# Altium schematic sheet Sheet1.SchDoc
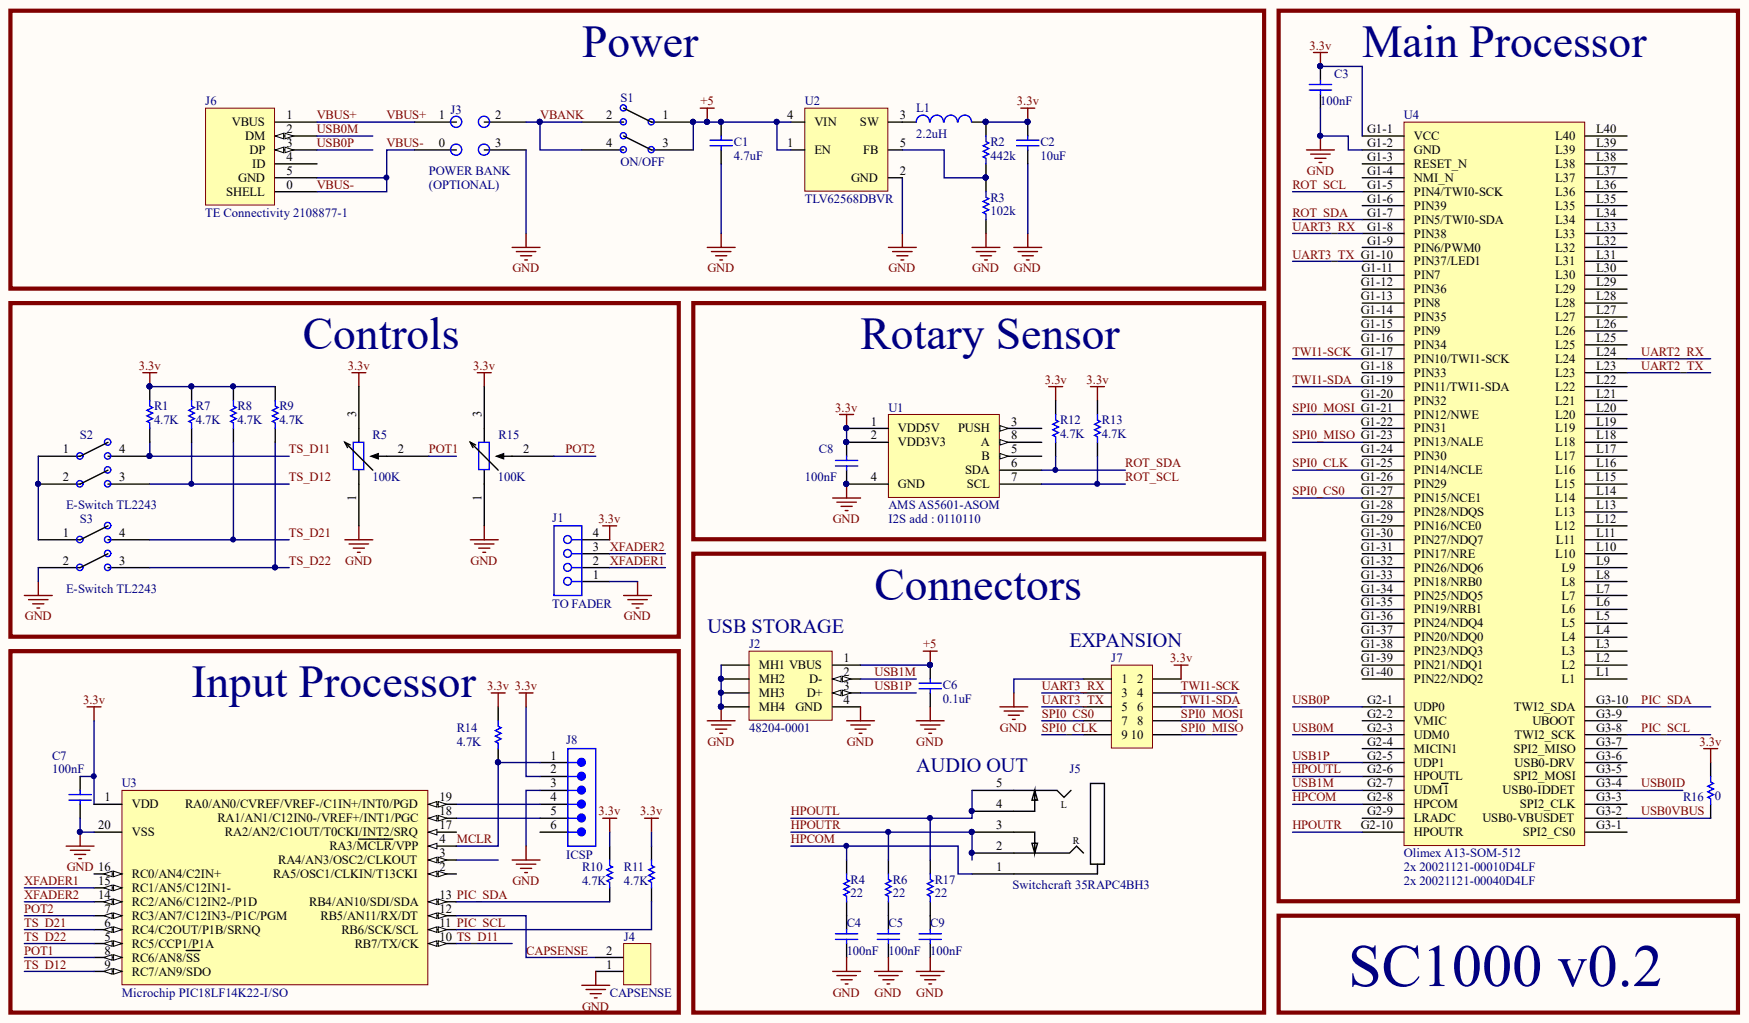
[diagram p0: Sheet1.SchDoc]

## Parts
C1  4.7uF  [CAPC3216X88X50NL20T25]
C2  10uF  [CAPC3216X180X50NL20T25]
C3  100nF  [CAPC3216X88X50NL20T25]
C4  100nF  [CAPC3216X88X50NL20T25]
C5  100nF  [CAPC3216X88X50NL20T25]
C6  0.1uF  [CAPC3216X110X50NL20T25]
C7  100nF  [CAPC3216X88X50NL20T25]
C8  100nF  [CAPC3216X88X50NL20T25]
C9  100nF  [CAPC3216X88X50NL20T25]
J1  TO FADER  [PCB-rr2vrocx47ltzxs94tsj-1]
J2  48204-0001  [MOLX-48204-0001_V]
J3  POWER BANK  [PCB-640863-1]
J4  CAPSENSE  [HDR102]
J5  Switchcraft 35RAPC4BH3  [PCB-i49478ydpijisqw62jjz-1]
J6  TE Connectivity 2108877-1  [PCB-swvmklzeh1x4bfefwydg-1]
J7  samtec TSW-105-07-G-D  [PCB-2.54]  (value not drawn)
J8  ICSP  [PCB-2481460-1]
L1  2.2uH  [PCB-fuzgio0l72uhvfuauccx-1]
R1  4.7K  [RESC3116X65X40NL10T20]
R2  442k  [RESC3116X65X40NL10T20]
R3  102k  [RESC3116X65X40NL10T20]
R4  22  [RESC3216X60X45NL10T20]
R5  100K  [PCB-shbx6jp5maipb9mq302d-1]
R6  22  [RESC3216X60X45NL10T20]
R7  4.7K  [RESC3116X65X40NL10T20]
R8  4.7K  [RESC3116X65X40NL10T20]
R9  4.7K  [RESC3116X65X40NL10T20]
R10  4.7K  [RESC3116X65X40NL10T20]
R11  4.7K  [RESC3116X65X40NL10T20]
R12  4.7K  [RESC3116X65X40NL10T20]
R13  4.7K  [RESC3116X65X40NL10T20]
R14  4.7K  [RESC3116X65X40NL10T20]
R15  100K  [PCB-shbx6jp5maipb9mq302d-1]
R16  0  [RESC1608X55X25NL10T15]
R17  22  [RESC3216X60X45NL10T20]
S1  ON/OFF  [PCB-6le2ne6zhzdyil25dd15-1]
S2  E-Switch TL2243  [PCB-9wmaaupyl5r06yccozck-1]
S3  E-Switch TL2243  Manufacturer Part Number: TL2243  [PCB-9wmaaupyl5r06yccozck-1]
U1  AMS AS5601-ASOM  [PCB-q2hh09f3jnaqliukgvym-1]
U2  TLV62568DBVR  [TLV62568DBVR]
U3  Microchip PIC18LF14K22-I/SO  Manufacturer Part Number: PIC18LF14K22-I/SO  [SOIC-SO20_N]
U4  Olimex A13-SOM-512  [PCB-ys24v96rmxr2imnz69ba-1]

## Nets
3.3V: C2.2 C3.2 C7.2 C8.2 J1.4 J7.2 J8.2 L1.2 R1.2 R2.2 R5.3 R7.2 R8.2 R9.2 R10.2 R11.2 R12.2 R13.2 R14.2 R15.3 R16.2 U1.1(VDD5V) U1.2(VDD3V3) U3.1(VDD) U4.G1-1(VCC)
+5: C1.2 C6.2 J2.1(VBUS) S1.1 S1.3 U2.1(EN) U2.4(VIN)
GND: C1.1 C2.1 C3.1 C4.1 C5.1 C6.1 C7.1 C8.1 C9.1 J1.1 J2.4(GND) J2.MH1 J2.MH2 J2.MH3 J2.MH4 J3.3 J4.1 J7.1 J8.3 R3.1 R5.1 R15.1 S3.2 U1.4(GND) U2.2(GND) U3.20(VSS) U4.G1-2(GND)
CAPSENSE: J4.2 U3.12(RB5/AN11/RX/DT)
HPCOM: J5.1 R4.2 U4.G2-8(HPCOM)
HPOUTL: J5.4 J5.5 R17.2 U4.G2-6(HPOUTL)
HPOUTR: J5.2 J5.3 R6.2 U4.G2-10(HPOUTR)
MCLR: J8.1 R14.1 U3.4(RA3/MCLR/VPP)
PIC_SCL: R11.1 U3.11(RB6/SCK/SCL) U4.G3-8(TWI2_SCK)
PIC_SDA: R10.1 U3.13(RB4/AN10/SDI/SDA) U4.G3-10(TWI2_SDA)
POT1: R5.2 U3.8(RC6/AN8/SS)
POT2: R15.2 U3.7(RC3/AN7/C12IN3-/P1C/PGM)
ROT_SCL: R13.1 U1.7(SCL) U4.G1-5(PIN4/TWI0-SCK)
ROT_SDA: R12.1 U1.6(SDA) U4.G1-7(PIN5/TWI0-SDA)
SPI0_CLK: J7.9 U4.G1-25(PIN14/NCLE)
SPI0_CS0: J7.7 U4.G1-27(PIN15/NCE1)
SPI0_MISO: J7.10 U4.G1-23(PIN13/NALE)
SPI0_MOSI: J7.8 U4.G1-21(PIN12/NWE)
TS_D11: R1.1 S2.4 U3.10(RB7/TX/CK)
TS_D12: R7.1 S2.3 U3.9(RC7/AN9/SDO)
TS_D21: R8.1 S3.4 U3.6(RC4/C2OUT/P1B/SRNQ)
TS_D22: R9.1 S3.3 U3.5(RC5/CCP1/P1A)
TWI1-SCK: J7.4 U4.G1-17(PIN10/TWI1-SCK)
TWI1-SDA: J7.6 U4.G1-19(PIN11/TWI1-SDA)
UART2_RX: U4.L24
UART2_TX: U4.L23
UART3_RX: J7.3 U4.G1-8(PIN38)
UART3_TX: J7.5 U4.G1-10(PIN37/LED1)
USB0ID: U4.G3-4(USB0-IDDET)
USB0M: J6.2(DM) U4.G2-3(UDM0)
USB0P: J6.3(DP) U4.G2-1(UDP0)
USB0VBUS: R16.1 U4.G3-2(USB0-VBUSDET)
USB1M: J2.2(D-) U4.G2-7(UDM1)
USB1P: J2.3(D+) U4.G2-5(UDP1)
VBANK: J3.2 S1.2 S1.4
VBUS+: J3.1 J6.1(VBUS)
VBUS-: J3.0 J6.0(SHELL) J6.5(GND)
XFADER1: J1.2 U3.15(RC1/AN5/C12IN1-)
XFADER2: J1.3 U3.14(RC2/AN6/C12IN2-/P1D)
NetC4_2: C4.2 R4.1
NetC5_2: C5.2 R6.1
NetC9_2: C9.2 R17.1
NetJ8_4: J8.4 U3.19(RA0/AN0/CVREF/VREF-/C1IN+/INT0/PGD)
NetJ8_5: J8.5 U3.18(RA1/AN1/C12IN0-/VREF+/INT1/PGC)
NetL1_1: L1.1 U2.3(SW)
NetR2_1: R2.1 R3.2 U2.5(FB)
NetS2_1: S2.1 S2.2 S3.1

Not connected: J6 4(ID); J8 6; U1 3(PUSH) 5(B) 8(A); U3 2(RA5/OSC1/CLKIN/T13CKI) 3(RA4/AN3/OSC2/CLKOUT) 16(RC0/AN4/C2IN+) 17(RA2/AN2/C1OUT/T0CKI/INT2/SRQ); U4 G1-3(RESET_N) G1-4(NMI_N) G1-6(PIN39) G1-9(PIN6/PWM0) G1-11(PIN7) G1-12(PIN36) G1-13(PIN8) G1-14(PIN35) G1-15(PIN9) G1-16(PIN34) G1-18(PIN33) G1-20(PIN32) G1-22(PIN31) G1-24(PIN30) G1-26(PIN29) G1-28(PIN28/NDQS) G1-29(PIN16/NCE0) G1-30(PIN27/NDQ7) G1-31(PIN17/NRE) G1-32(PIN26/NDQ6) G1-33(PIN18/NRB0) G1-34(PIN25/NDQ5) G1-35(PIN19/NRB1) G1-36(PIN24/NDQ4) G1-37(PIN20/NDQ0) G1-38(PIN23/NDQ3) G1-39(PIN21/NDQ1) G1-40(PIN22/NDQ2) G2-2(VMIC) G2-4(MICIN1) G2-9(LRADC) G3-1(SPI2_CS0) G3-3(SPI2_CLK) G3-5(SPI2_MOSI) G3-6(USB0-DRV) G3-7(SPI2_MISO) G3-9(UBOOT) L1 L2 L3 L4 L5 L6 L7 L8 L9 L10 L11 L12 L13 L14 L15 L16 L17 L18 L19 L20 L21 L22 L25 L26 L27 L28 L29 L30 L31 L32 L33 L34 L35 L36 L37 L38 L39 L40

## Library data of the parts
C1 = CMP-2511528-2: Capacitor 1206 4.7uF 16V; Manufacturer Part Number: C1206C475Z4VACTU; Capacitance: 4.7e-06 F; Dielectric Characteristic: Y5V; Dielectric Material: Ceramic; Lead-Free Status: Lead Free; Voltage Rating (DC): 16.0 V; Case/Package: 1206; Component Kind: Standard; Library Name: CommunityVault; Mounting Style: Surface Mount; OctopartID: f75e3e6f6bc22899; Octopart Url: https://octopart.com/c1206c475z4vac7800-kemet-3914234; RoHS: Compliant
C2 = CMP-3550774-2: Multilayer Ceramic Capacitors MLCC - SMD/SMT 22uF 10 Volts 20% X7R; Manufacturer Part Number: C1206C226M8RACTU; Capacitance: 2.2e-05 F; Lead-Free Status: R; Voltage Rating (DC): 10.0 V; Capacitance Tolerance: ±20%; Case/Package: 1206; Component Kind: Standard; Dielectric Characteristic: X7R; Dielectric Material: Ceramic; Library Name: CommunityVault; Mounting Style: Surface Mount; OctopartID: 149fdcc2ce7acb58; Octopart Url: https://octopart.com/c1206c226m8ractu-kemet-22544259; Packaging: Cut Tape (CT); REACH SVHC Compliance: No SVHC; RoHS: Compliant
C3-C5 C7-C9 = CMP-123891-2: CAP CER 0.1UF 50V 20% X7R 1206; Manufacturer Part Number: C1206C104M5RACTU; Capacitance: 1e-07 F; Case/Package (SI): 3216; Dielectric Material: Ceramic; Lead-Free Status: R; Voltage Rating (DC): 50.0 V; Capacitance Tolerance: ±20%; Case/Package: 1206; Component Kind: Standard; Dielectric Characteristic: X7R; Library Name: CommunityVault; Mounting Style: Surface Mount; Number of Pins: 2; OctopartID: 2f83e688f3a5f044; Octopart Url: https://octopart.com/c1206c104m5ractu-kemet-129344; Packaging: Cut Tape (CT); RoHS: Compliant; Size-Height: 0.00078 m; Size-Length: 0.0032 m; Size-Width: 0.0016 m
C6 = CMP-2511617-8: 1206 X7R ceramic capacitor, 1uF 16V; Manufacturer Part Number: C1206C105K4RACTU; Capacitance: 1e-06 F; Capacitance Tolerance: ±10%; Lead-Free Status: R; Voltage Rating (DC): 16.0 V; Case/Package: 1206; Component Kind: Standard; Dielectric Characteristic: X7R; Dielectric Material: Ceramic; Library Name: CommunityVault; Mounting Style: Surface Mount; OctopartID: bd2e741f34f862e9; Octopart Url: https://octopart.com/c1206c105k4rac7800-kemet-3910832; RoHS: Compliant
J1 = CMP-6785af82bd5db842-4: Conn Unshrouded Header HDR 4 POS 2.54mm Solder ST Thru-Hole C-Grid III™ Tray; Manufacturer Part Number: 0901200124; Component Kind: Standard; Contact Plating: Tin; Library Name: CommunityVault; OctopartID: 6785af82bd5db842; Octopart URL: http://octopart.com/0901200124-molex-17694654; Orientation: Straight; RoHS: Compliant
J2 = CMP-778514-3: USB Shielded I/O Receptacle, Type A; Current Rating: 1.0 A; Gender: Female; Number of Circuits: 4; Component Kind: Standard; Contact Material: Phosphor Bronze; Contact Plating: Gold; Halogen Free Status: Not Low Halogen; Library Name: CommunityVault; Lifecycle Status: Active; Manufacturer Part Number: 48204-0001; Number of Contacts: 4; Number of Rows: 3; OctopartID: 078fcef1f108d848; Octopart Url: https://octopart.com/48204-0001-molex+%2F+waldom-59165870; Orientation: Vertical; REACH SVHC Compliance: No SVHC; RoHS: Compliant
J3 = CMP-640863-5: CONN HEADER VERT 4POS .100 30AU; Manufacturer Part Number: 1-87215-1; ELV Compliant: Compliant; Gender: Male; Lead-Free Status: Lead Free; Mounting Angle: 180.0 ┬░; Number of Contacts: 4; Pin Pitch: 0.00254 m; Component Kind: Standard; Contact Material: Phosphor Bronze; Flammability Rating: UL 94 V-0; Housing Color: Black; Housing Material: Thermoplastic; Library Name: CommunityVault; Lifecycle Status: Active; Mounting Style: PCB; Number of Positions: 4; Number of Rows: 2; OctopartID: 443c8d3580ef9bfb; Octopart Url: http://octopart.com/1-87215-1-te+connectivity+%2F+amp-254389; Orientation: Vertical; Packaging: Bulk; RoHS: Compliant; Size-Length: 0.004674 m
J4 = CMP-4501201-4: CONN HEADER 2POS .100" SGL GOLD; Manufacturer Part Number: TSW-102-07-S-S; Gender: Male; Lead-Free Status: Lead Free; Number of Contacts: 2; Pin Pitch: 0.00254 m; Component Kind: Standard; Contact Material: Phosphor Bronze; Contact Plating: Gold; Housing Color: Black; Housing Material: Plastic; Library Name: CommunityVault; Mounting Style: Through Hole; Number of Positions: 2; Number of Rows: 1; OctopartID: 2036e9382d5dfff1; Octopart Url: https://octopart.com/tsw-102-07-s-s-samtec-272397; RoHS: Compliant; Size-Height: 0.00254 m; Size-Length: 0.00508 m; Size-Width: 0.00254 m
J5 = CMP-329445-15: Conn 3.5MM Stereo Jack F 5 POS Solder RA Thru-Hole 5 Terminal 1 Port; Component Kind: Standard; Library Name: FavoritesLibrary
J6 = CMP-8e0d441f584c51ca-6: Conn Micro USB 2.0 Type B RCP 5 POS Solder RA SMD 5 Terminal 1 Port T/R; Component Kind: Standard; Library Name: CommunityVault
J7 = CMP-fd383436ff4822ff-3: Conn Unshrouded Header HDR 10 POS 2.54mm Solder ST Thru-Hole Automotive Bulk; Lead-Free Status: Lead Free; Number of Contacts: 10; Color: Black; Component Kind: Standard; Contact Material: Phosphor Bronze; Contact Plating: Gold; Housing Color: Black; Housing Material: Plastic; Library Name: CommunityVault; Manufacturer Part Number: TSW-105-07-G-D; Mounting Style: Through Hole; Number of Positions: 10; Number of Rows: 2; OctopartID: fd383436ff4822ff; Octopart URL: http://octopart.com/tsw-105-07-g-d-samtec-272150; Packaging: Bulk; Pin Pitch: 2.54 mm; RoHS: Compliant; Size-Height: 2.54 mm; Size-Length: 12.7 mm; Size-Width: 5.08 mm
J8 = CMP-2481460-6: Conn Unshrouded Header HDR 6 POS 2.54mm Solder ST Thru-Hole Bag; Manufacturer Part Number: M20-9990646; Gender: Male; Number of Contacts: 6; Pin Pitch: 0.00254 m; Voltage Rating (AC): 750.0 V; Component Kind: Standard; Contact Material: Brass; Contact Plating: Tin; Library Name: CommunityVault; Mounting Style: Through Hole, Vertical; OctopartID: 6eb3a7ea58b7b174; Octopart URL: http://octopart.com/m20-9990646-harwin-5444450; REACH SVHC Compliance: No SVHC; RoHS: Compliant
L1 = CMP-7545bccc63e2aa82-1: Inductor Power Shielded Wirewound 2.2uH 30% 100KHz Ferrite 3.7A 26mOhm DCR 2424 T/R; Manufacturer Part Number: NR6028T2R2N; Inductance: 2.20 H; Self Resonant Frequency: 68.0 MHz; Component Kind: Standard; Halogen Free Status: Halogen Free; Library Name: CommunityVault; Lifecycle Status: Active; Mounting Style: Surface Mount; Number of Pins: 2; OctopartID: 7545bccc63e2aa82; Packaging: Cut Tape (CT); RoHS: Compliant
R1 R7-R14 = CMP-5390195-2: Thick Film Resistors 4.7K OHM 5%; Manufacturer Part Number: RC1206JR-134K7L; Lead-Free Status: Lead Free; Resistance: 4700.0 Ω; Case/Package: 1206; Component Kind: Standard; Library Name: CommunityVault; Mounting Style: Surface Mount; OctopartID: 3f50f6a201aae097; Octopart Url: https://octopart.com/rc1206jr-134k7l-yageo-16259996; Resistance Tolerance: ±5%; RoHS: Compliant
R2 = CMP-1855773-2: RES SMD 442K OHM 1% 1/4W 1206; Manufacturer Part Number: RC1206FR-07442KL; Case/Package (SI): 3216; Lead-Free Status: Lead Free; Power Rating: 0.25 W; Resistance: 442000.0 Ω; Voltage Rating (DC): 200.0 V; Case/Package: 1206; Component Kind: Standard; Library Name: CommunityVault; Mounting Style: Surface Mount; OctopartID: c4480d51f1ce1b8f; Octopart Url: https://octopart.com/rc1206fr-07442kl-yageo-1249504; Resistance Tolerance: ±1%; RoHS: Compliant; Size-Height: 0.00055 m; Size-Length: 0.0032 m; Size-Width: 0.0016 m
R3 = CMP-1855731-2: RES SMD 102K OHM 1% 1/4W 1206; Manufacturer Part Number: RC1206FR-07102KL; Case/Package (SI): 3216; Lead-Free Status: Lead Free; Power Rating: 0.25 W; Resistance: 102000.0 Ω; Voltage Rating (DC): 200.0 V; Case/Package: 1206; Component Kind: Standard; Library Name: CommunityVault; Mounting Style: Surface Mount; OctopartID: 02facbe32bf1dd3d; Octopart Url: https://octopart.com/rc1206fr-07102kl-yageo-1248365; Resistance Tolerance: ±1%; RoHS: Compliant; Size-Height: 0.00055 m; Size-Length: 0.0032 m; Size-Width: 0.0016 m
R4 R6 R17 = CMP-06e9cd93c4d2d92e-3: Surface Mount Thick Film Resistor, RC Series, 22 ohm, 250 mW, - 1%, 200 V, 1206 [3216 Metric]; Manufacturer Part Number: RC1206FR-0722RL; Case/Package (SI): 3216; Lead-Free Status: Lead Free; Power Rating: 250 mW; Resistance: 22.0 Ω; Voltage Rating (DC): 200 V; Case/Package: 1206; Component Kind: Standard; Library Name: CommunityVault; Mounting Style: Surface Mount; Number of Pins: 2; OctopartID: 06e9cd93c4d2d92e; Octopart URL: http://octopart.com/rc1206fr-0722rl-yageo-40953693; Packaging: Tape & Reel (TR), Cut Tape (CT); REACH SVHC Compliance: No SVHC; Resistance Tolerance: ±1%; RoHS: Compliant; Size-Height: 550 µm; Size-Length: 3.20 mm; Size-Width: 1.60 mm
R5 R15 = CMP-a3846bb417147c43-1: PTV09 Series 9mm Potentiometer 100K Ohm 20% 0.05 W 1(Elec)/1(Mech)Turn Bracket Mount/Thru-Hole Tray; Manufacturer Part Number: PTV09A-2020S-B104; Component Kind: Standard; Library Name: FavoritesLibrary; Mounting Style: Bracket, Through Hole; OctopartID: a3846bb417147c43; Octopart URL: https://octopart.com/ptv09a-2020s-b104-bourns-20615670; RoHS: Compliant
R16 = CMP-3759259-2: YAGEO   RC0603JR-100RL   THICK FILM RESISTOR, 0 OHM, 100mW, 5%; Lead-Free Status: Lead Free; Power Rating: 0.1 W; Resistance: 0.0 Ω; Voltage Rating (DC): 50.0 V; Case/Package: 0603; Component Kind: Standard; Library Name: CommunityVault; Manufacturer Part Number: RC0603JR-100RL; Mounting Style: Surface Mount; Number of Pins: 2; OctopartID: 6faba662d6acb06c; Octopart Url: https://octopart.com/rc0603jr-100rl-yageo-12819553; Packaging: Cut Tape (CT); Resistance Tolerance: ±5%; RoHS: Compliant; Size-Length: 0.0016 m
S1 = CMP-5e02924d985d81c5-2: PB400 Series DPST Black Capped Right Angle Latching Pushbutton Switch; Lead-Free Status: Lead Free; Component Kind: Standard; Library Name: CommunityVault
S2 S3 = CMP-599490f5d9408653-1: Switch Tactile N.O. SPST Round Button PC Pins 0.05A 12VDC 1.77N Thru-Hole; Contacts Type: DPDT; Lead-Free Status: Lead Free; Component Kind: Standard; Library Name: FavoritesLibrary; Mounting Style: PC Board; OctopartID: 599490f5d9408653; Octopart URL: https://octopart.com/tl2243-e-switch-7054770; RoHS: Compliant
  S2: Manufacturer Part Number: TL2243
U1 = CMP-bfed75fe9787602a-1: RotaryPosition Sensor 5.5V 8-Pin SOIC Tape and Reel; Component Kind: Standard; Library Name: CommunityVault; OctopartID: bfed75fe9787602a
U2 = CMP-1b92d60df203c455-4: 1-A High Efficiency Step-down Buck Converter in SOT23 Package 5-SOT-23 -40 to 125; Component Kind: Standard; Library Name: CommunityVault
U3 = CMP-1155955-2: Flash Microcontroller with nanoWatt XLP Technology, 20-pin SOIC, Industrial Temperature; Clock Speed: 64000000.0 Hz; FLASH Memory Size: 16384 B; Lead-Free Status: Lead Free; RAM Memory Size: 512 B; Component Kind: Standard; Library Name: CommunityVault; Lifecycle Status: Not Listed by Manufacturer; Mounting Style: Surface Mount; Number of Pins: 20; OctopartID: 89af402861a15668; Octopart Url: https://octopart.com/pic18lf14k22-i%2Fso-microchip-9293160; REACH SVHC Compliance: No SVHC; RoHS: Compliant
U4 = CMP-32r4v9r4g2a0b2vspqrc-1: Olimex A13-SOM-512 SoM; Component Kind: Standard; CustomComponentID: 32r4v9r4g2a0b2vspqrc; Library Name: FavoritesLibrary
